# Altium schematic sheet HIP4081 Motor Board.SchDoc("HIP4081 Motor Board")
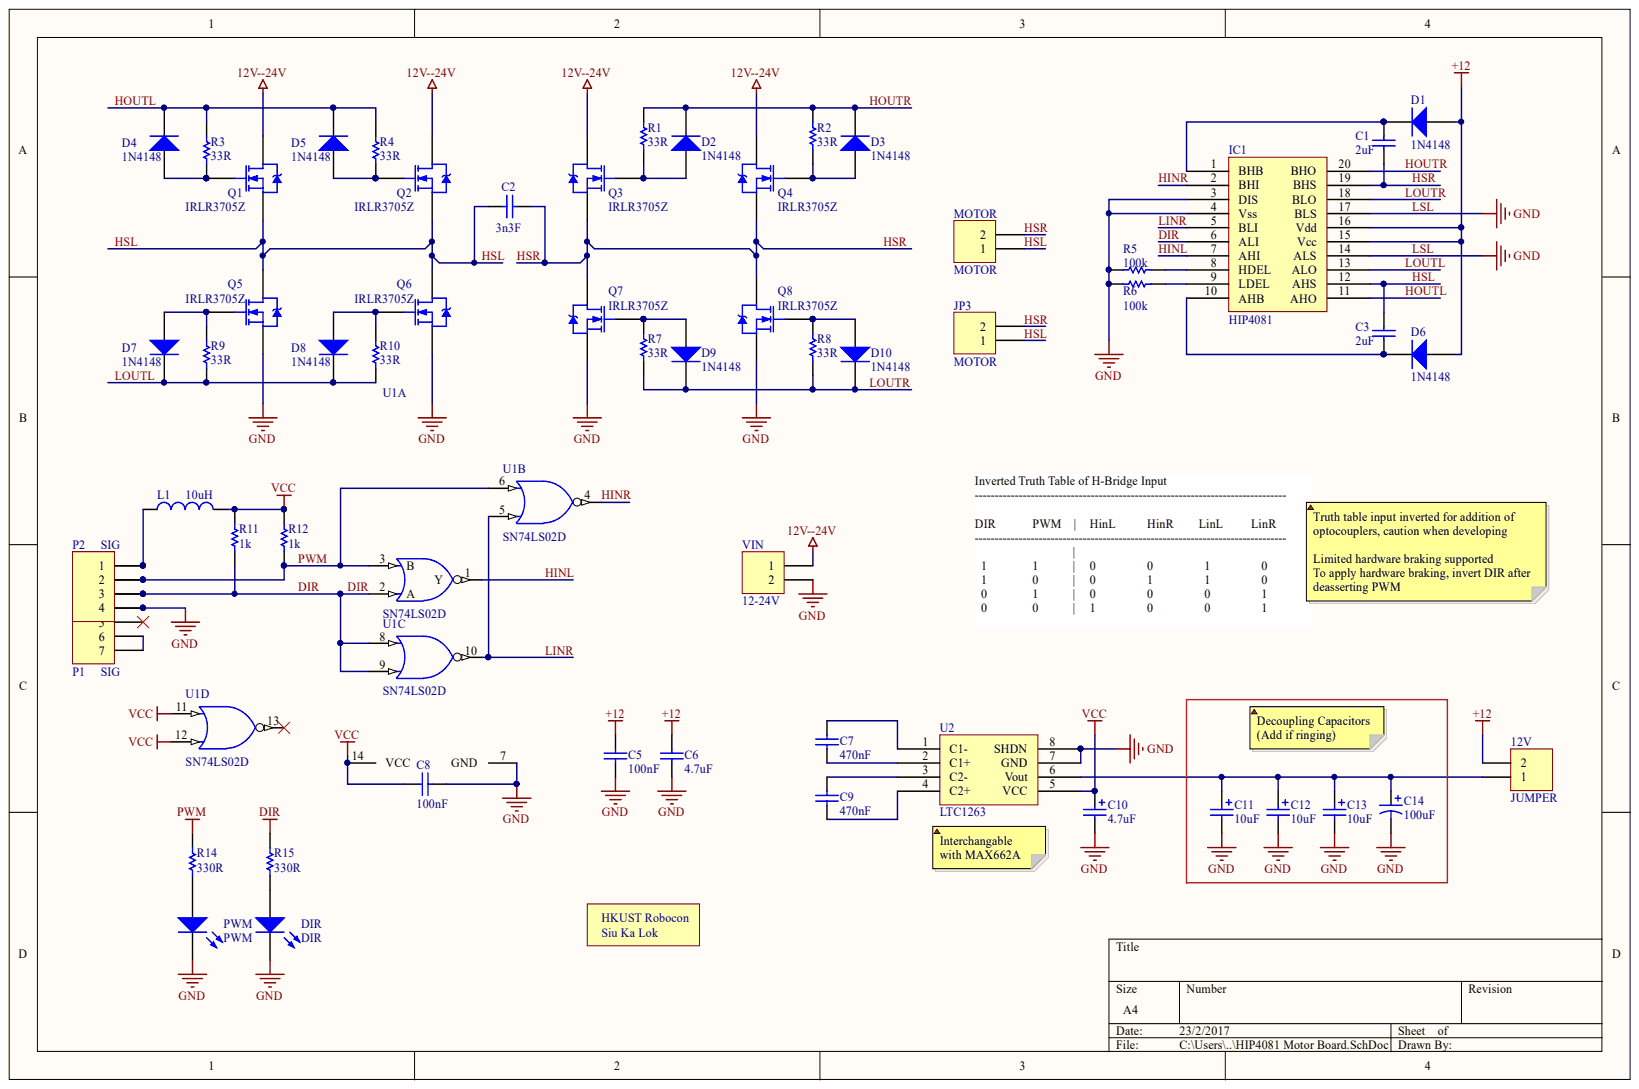
[diagram p0: HIP4081 Motor Board.SchDoc]

## Parts
12V  JUMPER  [6410-02]
C1  2uF  [CC3216-1206]
C2  3n3F  [CC3225-1210]
C3  2uF  [CC3216-1206]
C5  100nF  [CC2012-0805]
C6  4.7uF  [CC3216-1206]
C7  470nF  [CC2012-0805]
C8  100nF  [CC2012-0805]
C9  470nF  [CC2012-0805]
C10  4.7uF  [CR3216-1206]
C11  10uF  [CR3216-1206]
C12  10uF  [CR3216-1206]
C13  10uF  [CR3216-1206]
C14  100uF  [EIA7343]
D1  1N4148  [MURS120]
D2  1N4148  [MURS120]
D3  1N4148  [MURS120]
D4  1N4148  [MURS120]
D5  1N4148  [MURS120]
D6  1N4148  [MURS120]
D7  1N4148  [MURS120]
D8  1N4148  [MURS120]
D9  1N4148  [MURS120]
D10  1N4148  [MURS120]
DIR  DIR  [SMD _LED]
IC1  HIP4081  [SOP-20]
JP3  MOTOR  [T-CONNECTOR(F)]
L1  value 10uH  [CR3225-1210]  (hidden comment: Inductor)
MOTOR  MOTOR  [LOCKEDPWRHDR]
P1  SIG  [HDR1X7]
P2  SIG  [LOCKEDHDR-4W]
Project1  Robocon Logo  [Robocon_Logo]  (value not drawn)
PWM  PWM  [SMD _LED]
Q1  IRLR3705Z  [TO-252AA]
Q2  IRLR3705Z  [TO-252AA]
Q3  IRLR3705Z  [TO-252AA]
Q4  IRLR3705Z  [TO-252AA]
Q5  IRLR3705Z  [TO-252AA]
Q6  IRLR3705Z  [TO-252AA]
Q7  IRLR3705Z  [TO-252AA]
Q8  IRLR3705Z  [TO-252AA]
R1  33R  [CR2012-0805]
R2  33R  [CR2012-0805]
R3  33R  [CR2012-0805]
R4  33R  [CR2012-0805]
R5  100k  [CR2012-0805]
R6  100k  [CR2012-0805]
R7  33R  [CR2012-0805]
R8  33R  [CR2012-0805]
R9  33R  [CR2012-0805]
R10  33R  [CR2012-0805]
R11  1k  [CR2012-0805]
R12  1k  [CR2012-0805]
R14  330R  [CR2012-0805]
R15  330R  [CR2012-0805]
U1  SN74LS02D  [D014]  (parts A,B,C,D)
U2  LTC1263  [SO-8]
VIN  12-24V  [T-CONNECTOR(M)]

## Nets
12V--24V: Q1.4 Q2.4 Q3.4 Q4.4 VIN.1
+12: 12V.2 C5.2 C6.2 D1.1 D6.1 IC1.15(Vcc) IC1.16(Vdd)
VCC: C8.2 C10.1 L1.2 R11.2 R12.2 U1.11 U1.12 U1.14(VCC) U2.5(VCC)
DIR: IC1.6(ALI) P1.3 P2.3 R11.1 R15.2 U1.2(A) U1.8 U1.9
HINL: IC1.7(AHI) U1.1(Y)
HINR: IC1.2(BHI) U1.4
HOUTL: D4.2 D5.2 IC1.11(AHO) R3.1 R4.1
HOUTR: D2.2 D3.2 IC1.20(BHO) R1.2 R2.2
HSL: C2.2 C3.1 IC1.12(AHS) JP3.1 MOTOR.1 Q1.3 Q2.3 Q5.4 Q6.4
HSR: C1.2 C2.1 IC1.19(BHS) JP3.2 MOTOR.2 Q3.3 Q4.3 Q7.4 Q8.4
LINR: IC1.5(BLI) U1.5 U1.10
LOUTL: D7.2 D8.2 IC1.13(ALO) R9.2 R10.2
LOUTR: D9.2 D10.2 IC1.18(BLO) R7.1 R8.1
LSL: C5.1 C6.1 C8.1 C10.2 C11.2 C12.2 C13.2 C14.2 DIR.2 IC1.3(DIS) IC1.4(Vss) IC1.14(ALS) IC1.17(BLS) P1.4 P2.4 PWM.2 Q5.3 Q6.3 Q7.3 Q8.3 R5.2 R6.2 U1.7(GND) U2.7(GND) U2.8(SHDN) VIN.2
PWM: P1.2 P2.2 R12.1 R14.2 U1.3(B) U1.6
Net12V_1: 12V.1 C11.1 C12.1 C13.1 C14.1 U2.6(Vout)
NetC1_1: C1.1 D1.2 IC1.1(BHB)
NetC3_2: C3.2 D6.2 IC1.10(AHB)
NetC7_1: C7.1 U2.2(C1+)
NetC7_2: C7.2 U2.1(C1-)
NetC9_1: C9.1 U2.4(C2+)
NetC9_2: C9.2 U2.3(C2-)
NetD2_1: D2.1 Q3.1 R1.1
NetD3_1: D3.1 Q4.1 R2.1
NetD4_1: D4.1 Q1.1 R3.2
NetD5_1: D5.1 Q2.1 R4.2
NetD7_1: D7.1 Q5.1 R9.1
NetD8_1: D8.1 Q6.1 R10.1
NetD9_1: D9.1 Q7.1 R7.2
NetD10_1: D10.1 Q8.1 R8.2
NetDIR_1: DIR.1 R15.1
NetIC1_8: IC1.8(HDEL) R5.1
NetIC1_9: IC1.9(LDEL) R6.1
NetL1_1: L1.1 P1.1 P2.1
NetP1_6: P1.6 P1.7
NetPWM_1: PWM.1 R14.1

Not connected: P1 5; U1 13

## Library data of the parts
12V JP3 MOTOR VIN = Header 2: Header, 2-Pin; Component Kind: Standard; Library Name: Robocn_connectors.IntLib; Revision: 17-Jul-2002: Re-released for DXP Platform.; Signal Integrity: Connector; Publisher: Altium Limited
C1-C3 C5-C9 = Cap Semi: Capacitor (Semiconductor SIM Model); Component Kind: Standard; LatestRevisionDate: 17-Jul-2002; LatestRevisionNote: Re-released for DXP Platform.; Library Name: Robocon_RCL.IntLib; PackageReference: C1206; Published: 8-Jun-2000; Signal Integrity: Cap Semi; Simulation: CAP; Publisher: Altium Limited
C10-C13 = Cap Pol3: Polarized Capacitor (Surface Mount); Component Kind: Standard; LatestRevisionDate: 17-Jul-2002; LatestRevisionNote: Re-released for DXP Platform.; Library Name: Robocon_RCL.IntLib; PackageReference: C0805; Published: 8-Jun-2000; Signal Integrity: Cap Pol; Simulation: CAP; Publisher: Altium Limited
C14 = Cap Pol2: Polarized Capacitor (Axial); Component Kind: Standard; LatestRevisionDate: 17-Jul-2002; LatestRevisionNote: Re-released for DXP Platform.; Library Name: Robocon_RCL.IntLib; Published: 8-Jun-2000; Signal Integrity: Cap Pol; Simulation: CAP; Publisher: Altium Limited
D1-D10 = Diode 1N4148: High Conductance Fast Diode; Code - JEDEC: DO-204-AH; Revision 1: 11-Jul-2000: SIM Model Added.; Revision 2: 17-Jul-2002: Re-released for DXP Platform.; Component Kind: Standard; Datasheet: Latest Revision: 1997; Library Name: Robocon_BJT.IntLib; Package Reference: DO-35; Published: 8-Jun-2000; Signal Integrity: 1N4148; Simulation: 1N4148; Publisher: Altium Limited
DIR PWM = LED: Typical RED GaAs LED; Component Kind: Standard; LatestRevisionDate: 08-Jul-2005; LatestRevisionNote: Mech Layer 1 removed.; Library Name: Robocon_BJT.IntLib; PackageReference: LED-1; Published: 8-Jun-2000; Simulation: LED1; Publisher: Altium Limited
IC1 = HIP4081: 80V/2.5A Peak, High Frequency Full / Bridge FET Driver; Component Kind: Standard; Library Name: Robocon_IC.IntLib
P1 = Header 7: Header, 6-Pin; Component Kind: Standard; LatestRevisionDate: 17-Jul-2002; LatestRevisionNote: Re-released for DXP Platform.; Library Name: Robocn_connectors.IntLib; Publisher: Altium Limited
P2 = Header 4: Header, 4-Pin; Component Kind: Standard; Library Name: Robocn_connectors.IntLib; Revision: 17-Jul-2002: Re-released for DXP Platform.; Signal Integrity: Connector; Publisher: Altium Limited
Q1-Q8 = IRLR3705: HEXFET N-Channel Power MOSFET; Note: Pin 2 is trimmed and is electrically connected to Drain.; Code_JEDEC: TO-252-AA; Component Kind: Standard; DatasheetDocument: Nov-1998; LatestRevisionDate: 17-Jul-2002; LatestRevisionNote: Re-released for DXP Platform.; Library Name: Robocon_BJT.IntLib; PackageReference: TO-252AA; Published: 8-Jun-2000; Publisher: Altium Limited
R1-R12 R14 R15 = Res Semi: Semiconductor Resistor; Component Kind: Standard; LatestRevisionDate: 17-Jul-2002; LatestRevisionNote: Re-released for DXP Platform.; Library Name: Robocon_RCL.IntLib; Published: 8-Jun-2000; Signal Integrity: Res Semi; Simulation: RES; Publisher: Altium Limited
U1 = SN74LS02D: Quadruple 2-Input Positive-NOR Gate; Package Information: Latest Revision: Jan-1998; Component Kind: Standard; Datasheet: Latest Revision: Mar-1988; Library Name: Robocon_IC.IntLib; Package Reference: D014; Published: 8-Jun-2000; Revision: 17-Jul-2002: Re-released for DXP Platform.; Signal Integrity: SN74LS02D; Publisher: Altium Limited
U2 = MAX662A: 5V to 12V; Component Kind: Standard; Library Name: Robocon_IC.IntLib
L1 = Inductor: Component Kind: Standard; Description: Inductor; Library Name: Robocon_RCL.IntLib; Published: 8-Jun-2000; Revision: July-2002: Re-released for DXP Platform.; Signal Integrity: Inductor; Simulation: INDUCTOR; Publisher: Altium Limited
Project1 = Robocon Logo: Component Kind: Standard; Library Name: Robocon_RCL.IntLib
